# Altium schematic sheet sd_card.SchDoc(sd_card)
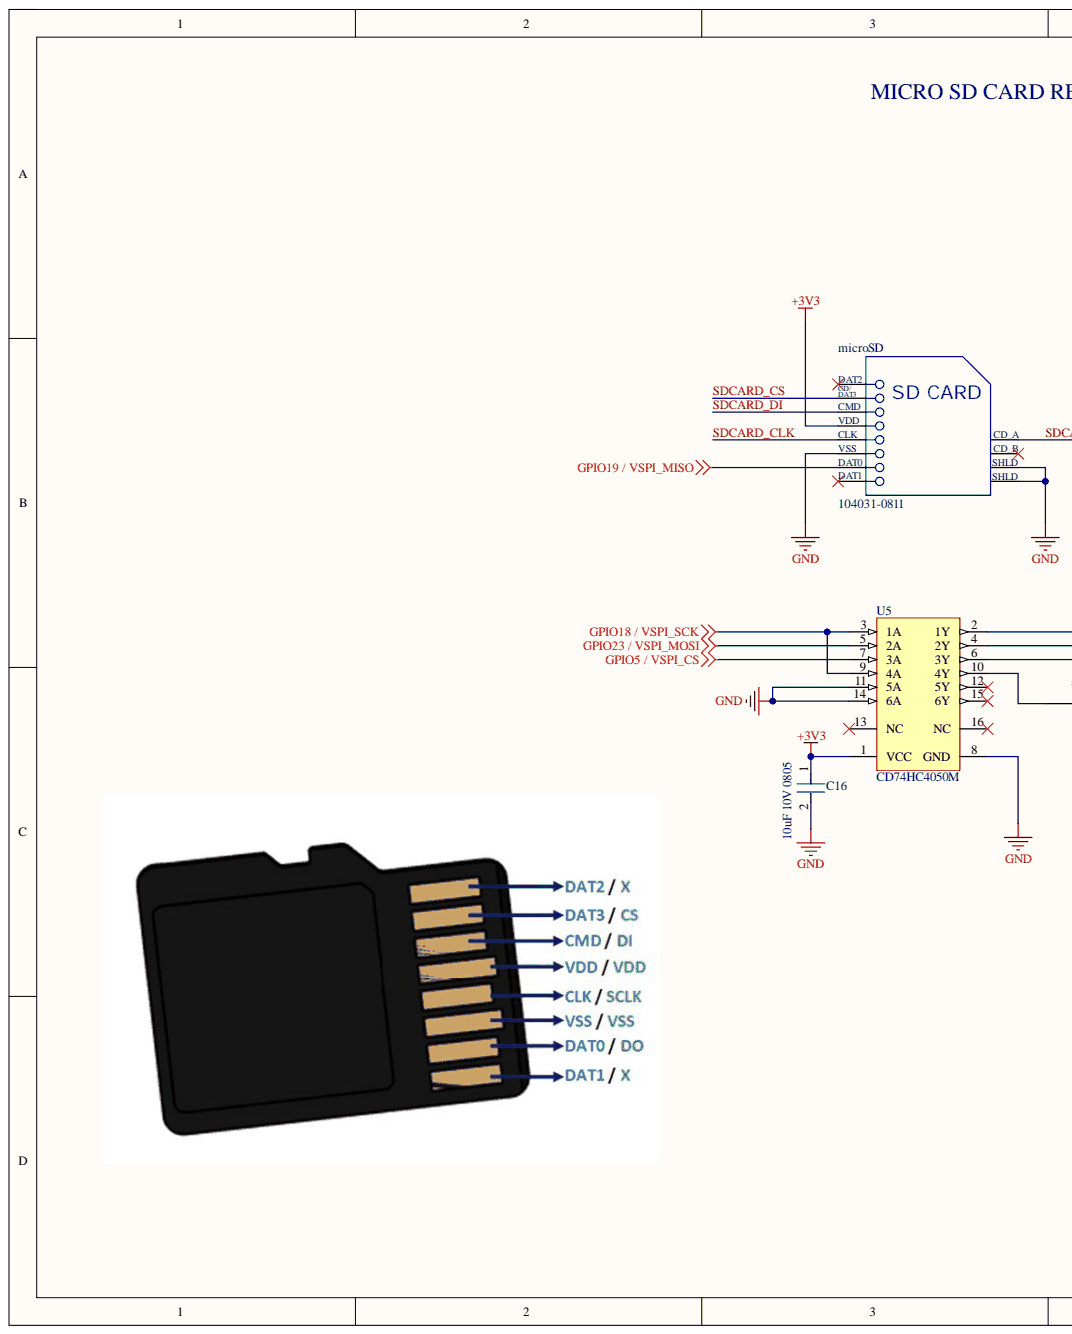
[diagram p0: sd_card.SchDoc, left]
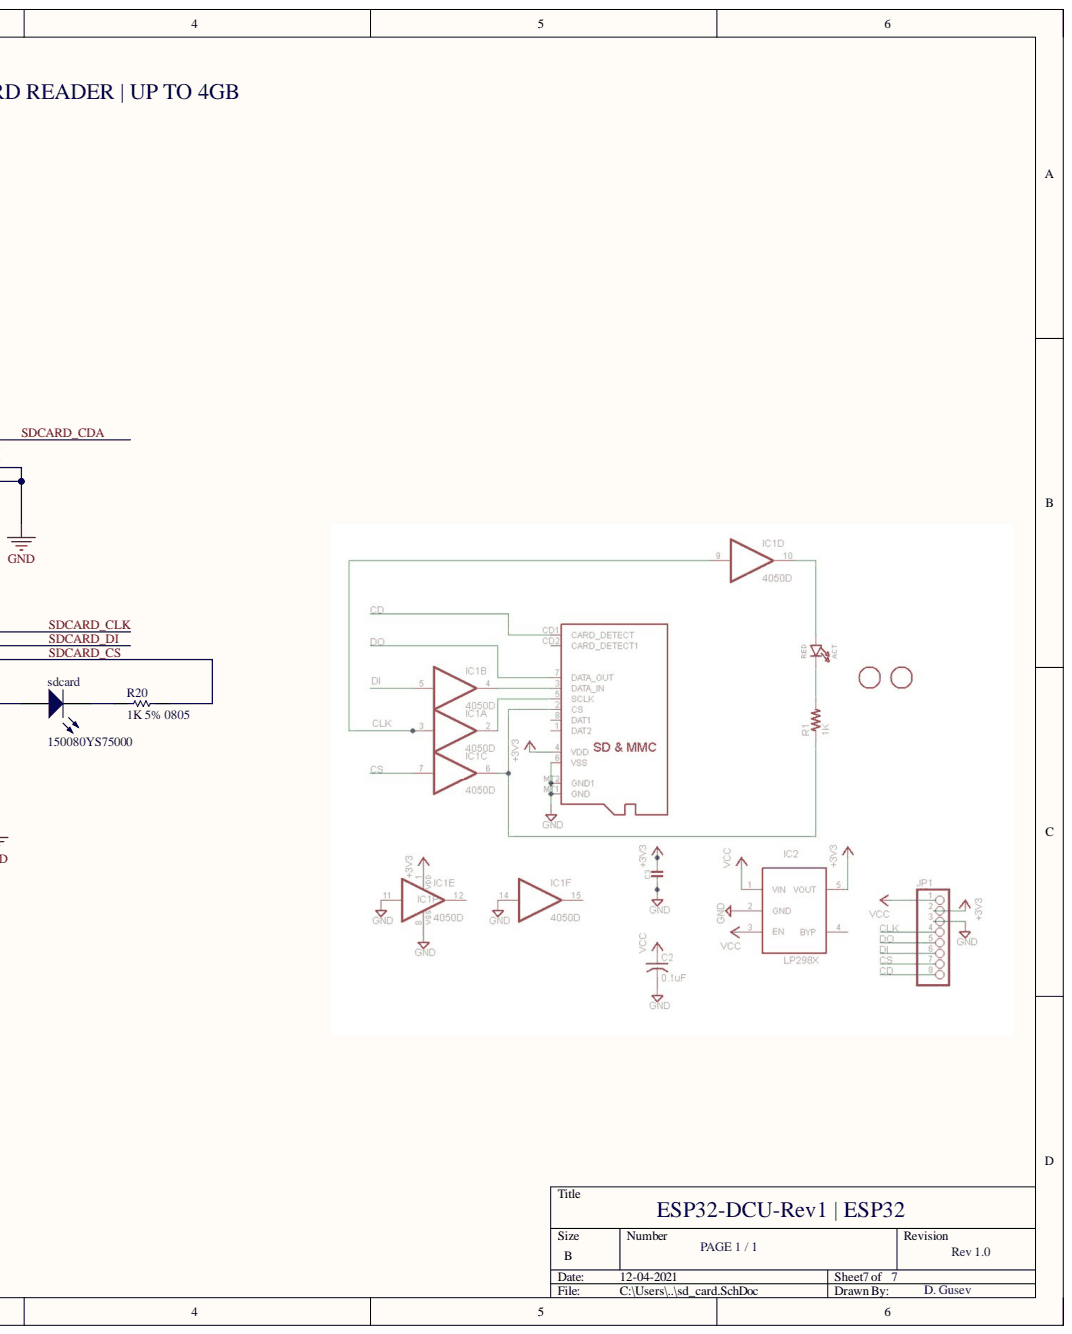
[diagram p1: sd_card.SchDoc, right]

## Parts
C16  10uF 10V 0805  value 10uF  Capacitance: 10uF  Voltage: 10V  [FP-C0805C-DG-MFG]
microSD  104031-0811  Card Type: microSD  [MOLX-104031-0811_V]
R20  1K 5% 0805  [RESC2013X60X40ML20T25]
sdcard  150080YS75000  [0805_A]
U5  CD74HC4050M  [D0016A_M]

## Nets
+3V3: C16.1 microSD.4 U5.1(VCC)
GND: C16.2 microSD.6 microSD.11 microSD.12 U5.8(GND) U5.11(5A) U5.14(6A)
GPIO5 / VSPI_CS: U5.7(3A)
GPIO18 / VSPI_SCK: U5.3(1A) U5.9(4A)
GPIO19 / VSPI_MISO: microSD.7
GPIO23 / VSPI_MOSI: U5.5(2A)
SDCARD_CDA: microSD.9
SDCARD_CLK: microSD.5 U5.2(1Y)
SDCARD_CS: microSD.2(SD_CARD) R20.2 U5.6(3Y)
SDCARD_DI: microSD.3 U5.4(2Y)
NetR20_1: R20.1 sdcard.1
Netsdcard_2: sdcard.2 U5.10(4Y)

Not connected: microSD 1 8 10; U5 12(5Y) 13(NC) 15(6Y) 16(NC)

## Library data of the parts
C16 = CMP-2007-03243-2: CAP CER 10UF 10V X5R 0805; 3DS Part Number: 220-00001; Manufacturer: Kemet; Manufacturer Part Number: C0805C106K8PACTU; Material: Ceramic; Temperature Coefficient: 15%; Tolerance: 10%; Automotive: No; Category: Cap; Component Kind: Standard; ComponentLink2Description: Datasheet; ComponentLink2URL: https://content.kemet.com/datasheets/KEM_C1006_X5R_SMD.pdf; Device Class L1: Passive Components; Device Class L2: Capacitors; Device Class L3: Ceramic Capacitors; Digikey Description: CAP CER 10UF 10V X5R 0805; Height: 1.4mm; Ipc Land Pattern Name: CAPC200125X125; Lead Free: Yes; Library Name: Altium Content Vault; Mouser Description: CAP CER 10UF 10V X5R 0805; Octopart: https://octopart.com/c0805c106k8pactu-kemet-98697; Package: C0805C-DG; RoHS: TRUE; Temperature: -55°C to +85°C; Temperature Characteristic: X5R; Temperature Range High: +85°C; Temperature Range Low: -55°C; Voltage Rating: 10V
microSD = CMP-2000-05825-1: Micro SD Card, RA, -25 to 85 degC, 8-Pin SMD, RoHS, Tape and Reel; Brand: Molex; Current Rating: 500mA; Insertion - Extraction Style: Push-Pull; Mating Cycles: 10000; Number of Contacts: 8; Pitch: 1.1mm; Voltage Rating: 10VAC/DC; Component Kind: Standard; Contact Material: PhosphorBronze; Contact Plating: Gold; Datasheet URL: http://www.molex.com/pdm_docs/sd/1040310811_sd.pdf; Datasheet Version: Rev. E, 04/2006; Height: 1.42mm; Housing Material: LiquidCrystalPolymer(LCP); Length: 11.4mm; Library Name: Altium Content Vault; Manufacturer: Molex; Manufacturer URL: http://www.molex.com/; Mounting Technology: SurfaceMount; Mounting Type: Standard; Number of Rows: 1Row; Orientation: RightAngle; Package Description: 8-Pin Surface Mount Device, Body 11.95 x 11.4 mm, Pitch 1.1 mm; Package Reference: 104031-0811; Packaging: Reel; Product: CardConnectors; Product Category: MemoryCardConnectors; RoHS: True; Series: 104031; Termination Style: SMD
R20 = CMP-2001-00590-1: Manufacturer: Panasonic; Part Number: ERA6AEB123V; Component Kind: Standard; Library Name: Altium Content Vault
sdcard = CMP-1426-00012-1: SMD mono-color Chip LED, WL-SMCW, Yellow; Manufacturer: Wuerth Elektronik; Color: Yellow; Component Kind: Standard; ComponentLink1Description: Manufacturer URL; ComponentLink1URL: http://www.we-online.com; ComponentLink2Description: Datasheet; ComponentLink2URL: http://katalog.we-online.com/led/datasheet/150080YS75000.pdf; Library Name: Altium Content Vault; PackageReference: 0805_A; PartNumber: 150080YS75000
U5 = CMP-0440-00356-3: High Speed CMOS Logic Hex Non-Inverting Buffers, D0016A, TUBE; Manufacturer: Texas Instruments; Bits(#): 6; F @ Nom Voltage(Max)(Mhz): 28; ICC @ Nom Voltage(Max)(mA): 0.02; Rating: Catalog; tpd @ Nom Voltage(Max)(ns): 18; VCC(Min)(V): 2; Code_JEDEC: MS-012 variation AC; Component Kind: Standard; DatasheetVersion: SCHS205I; Generic Part Number: CD74HC4050; Input Type: CMOS; Library Name: Altium Content Vault; Operating Temperature Range(C): -55 to 125; Output Type: CMOS; PackageReference: D0016A; PackageVersion: http://www.ti.com/lit/ml/mpds178g/mpds178g.pdf; VCC(Max)(V): 6; Voltage(Nom)(V): 6
